# Altium schematic sheet Sheet1.SchDoc
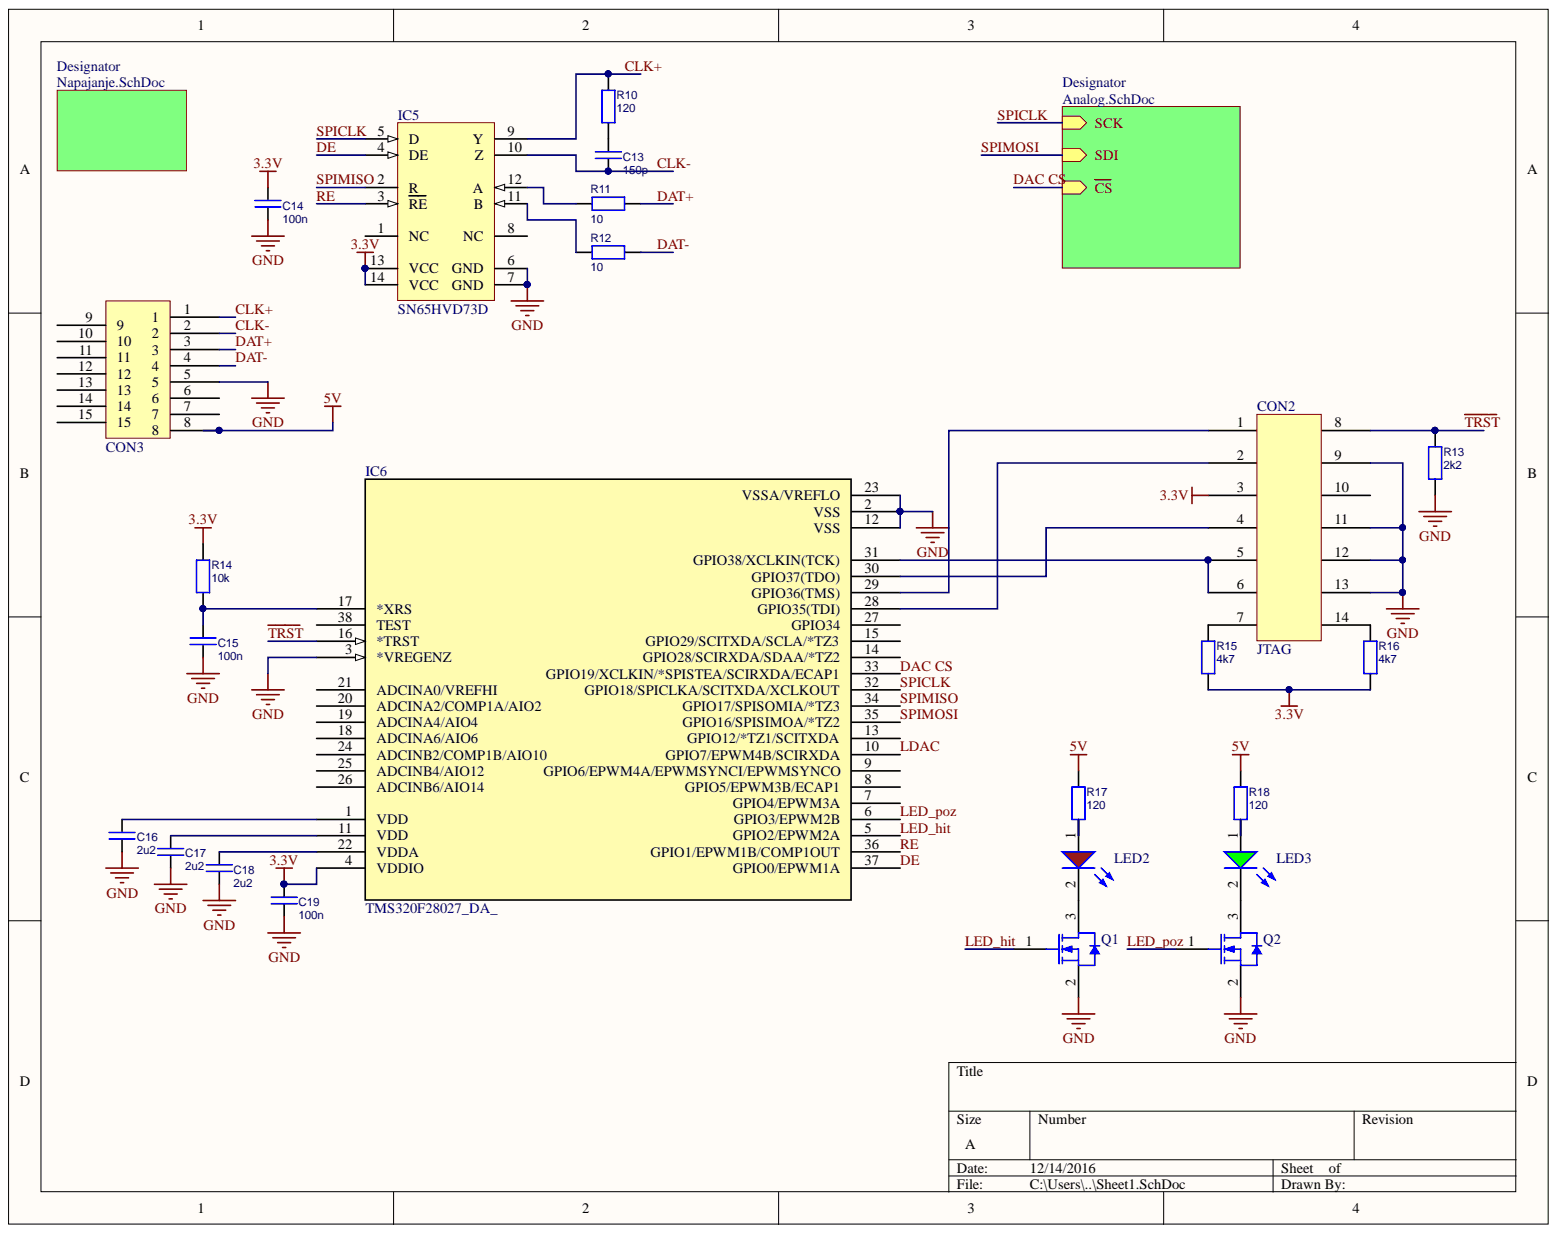
[diagram p0: Sheet1.SchDoc]

## Parts
C13  150p  [C0603]
C14  100n  [C0603]
C15  100n  [C0603]
C16  2u2  [C0603]
C17  2u2  [C0603]
C18  2u2  [C0603]
C19  100n  [C0603]
CON2  JTAG  [JTAG micromatch]
CON3  DSUbConector  [DSUB conector]  (value not drawn)
IC5  SN65HVD73D  [SN75180]
IC6  TMS320F28027_DA_  [TSOP65P810X120-38N]
LED2  150060RS75000  [LED_0603_Red]  (value not drawn)
LED3  150060VS75000  [LED_0603_Bright Green]  (value not drawn)
Q1  BSN20,215  [NXP-SOT23_N]  (value not drawn)
Q2  BSN20,215  [NXP-SOT23_N]  (value not drawn)
R10  120  [R0603]
R11  10  [R0603]
R12  10  [R0603]
R13  value 2k2  [R0805]  (hidden comment: 120)
R14  value 10k  [R0805]  (hidden comment: 120)
R15  value 4k7  [R0805]
R16  value 4k7  [R0805]
R17  120  [R0805]
R18  120  [R0805]

## Nets
3.3V: C14.1 C19.1 CON2.3 IC5.13(VCC) IC5.14(VCC) IC6.4(VDDIO) R14.1 R15.1 R16.1
5V: CON3.8 R17.1 R18.1
GND: C14.2 C15.2 C16.2 C17.2 C18.2 C19.2 CON2.9 CON2.11 CON2.12 CON2.13 CON3.5 IC5.6(GND) IC5.7(GND) IC6.2(VSS) IC6.3(*VREGENZ) IC6.12(VSS) IC6.23(VSSA/VREFLO) Q1.2 Q2.2 R13.1
CLK+: CON3.1 IC5.9(Y) R10.1
CLK-: C13.2 CON3.2 IC5.10(Z)
DAC CS: IC6.33(GPIO19/XCLKIN/*SPISTEA/SCIRXDA/ECAP1)
DAT+: CON3.3 R11.1
DAT-: CON3.4 R12.1
DE: IC5.4(DE) IC6.37(GPIO0/EPWM1A)
LDAC: IC6.10(GPIO7/EPWM4B/SCIRXDA)
LED_HIT: IC6.5(GPIO2/EPWM2A) Q1.1
LED_POZ: IC6.6(GPIO3/EPWM2B) Q2.1
RE: IC5.3(RE) IC6.36(GPIO1/EPWM1B/COMP1OUT)
SPICLK: IC5.5(D) IC6.32(GPIO18/SPICLKA/SCITXDA/XCLKOUT)
SPIMISO: IC5.2(R) IC6.34(GPIO17/SPISOMIA/*TZ3)
SPIMOSI: IC6.35(GPIO16/SPISIMOA/*TZ2)
~{TRST}: CON2.8 IC6.16(*TRST) R13.2
NetC13_1: C13.1 R10.2
NetC15_1: C15.1 IC6.17(*XRS) R14.2
NetC16_1: C16.1 IC6.1(VDD)
NetC17_1: C17.1 IC6.11(VDD)
NetC18_1: C18.1 IC6.22(VDDA)
NetCON2_1: CON2.1 IC6.29(GPIO36(TMS))
NetCON2_2: CON2.2 IC6.28(GPIO35(TDI))
NetCON2_4: CON2.4 IC6.30(GPIO37(TDO))
NetCON2_5: CON2.5 CON2.6 IC6.31(GPIO38/XCLKIN(TCK))
NetCON2_7: CON2.7 R15.2
NetCON2_10: CON2.10
NetCON2_14: CON2.14 R16.2
NetCON3_6: CON3.6
NetCON3_7: CON3.7
NetCON3_9: CON3.9
NetCON3_10: CON3.10
NetCON3_11: CON3.11
NetCON3_12: CON3.12
NetCON3_13: CON3.13
NetCON3_14: CON3.14
NetCON3_15: CON3.15
NetIC5_1: IC5.1(NC)
NetIC5_8: IC5.8(NC)
NetIC5_11: IC5.11(B) R12.2
NetIC5_12: IC5.12(A) R11.2
NetIC6_7: IC6.7(GPIO4/EPWM3A)
NetIC6_8: IC6.8(GPIO5/EPWM3B/ECAP1)
NetIC6_9: IC6.9(GPIO6/EPWM4A/EPWMSYNCI/EPWMSYNCO)
NetIC6_13: IC6.13(GPIO12/*TZ1/SCITXDA)
NetIC6_14: IC6.14(GPIO28/SCIRXDA/SDAA/*TZ2)
NetIC6_15: IC6.15(GPIO29/SCITXDA/SCLA/*TZ3)
NetIC6_18: IC6.18(ADCINA6/AIO6)
NetIC6_19: IC6.19(ADCINA4/AIO4)
NetIC6_20: IC6.20(ADCINA2/COMP1A/AIO2)
NetIC6_21: IC6.21(ADCINA0/VREFHI)
NetIC6_24: IC6.24(ADCINB2/COMP1B/AIO10)
NetIC6_25: IC6.25(ADCINB4/AIO12)
NetIC6_26: IC6.26(ADCINB6/AIO14)
NetIC6_27: IC6.27(GPIO34)
NetIC6_38: IC6.38(TEST)
NetLED2_1: LED2.1 R17.2
NetLED2_2: LED2.2 Q1.3
NetLED3_1: LED3.1 R18.2
NetLED3_2: LED3.2 Q2.3

## Library data of the parts
C13-C19 = C: Capacitor; Component Kind: Standard; Library Name: General.IntLib
  C13: Value: 150p
  C14 C15 C19: Value: 100n
  C16-C18: Value: 2u2
IC5 = SN65HVD55DR: High Output Full-Duplex RS-485 Driver and Receiver, 1 Mbps, 5 V, -40 to 85 degC, 14-pin SOIC (D), Green (RoHS & no Sb/Br); Manufacturer: Texas Instruments; # of TX/RX: 1 TX / 1 RX; Common Mode Range: -7 to 12; Drivers Per Package: 1; Duplex: Full; ESD(kV): 16; Fail Safe: Idle, Open, Short; Fault Protection(V): -9 to 14; ICC(Max)(mA): 8; Number of Nodes: 256; Rating: Catalog; Supply Voltage(s)(V): 5; Code_JEDEC: MS-012AB; Component Kind: Standard; ComponentLink1Description: Datasheet; ComponentLink1URL: http://www.ti.com/general/docs/lit/getliterature.tsp?genericPartNumber=SN65HVD55&fileType=pdf; ComponentLink2Description: Package Specification; ComponentLink2URL: http://www.ti.com/litv/pdf/mpds177g; DatasheetVersion: SLLS666E; Eco-Plan: Green (RoHS & no Sb/Br); Isolated: No; Library Name: Texas Instruments Interface RS-485.IntLib; Mounting Technology: Surface Mount; PackageDescription: 14-Pin Plastic Small Outline Integrated Circuit, 8.7 x 3.9 mm, Pitch 1.27 mm Pitch; PackageReference: D14; PackageVersion: revM, Jun-2011; PartNumber: SN65HVD55DR; Receivers Per Package: 1; Signaling Rate(Mbps): 1; SubFamily: RS-485; Supplier 1: Digi-Key; Supplier 2: Digi-Key; Supplier 3: Digi-Key; Supplier 4: Mouser; Supplier 5: Farnell; Supplier Part Number 1: 296-18709-2-ND; Supplier Part Number 2: 296-18709-1-ND; Supplier Part Number 3: 296-18709-6-ND; Supplier Part Number 4: 595-SN65HVD55DR; Supplier Part Number 5: 2455484
IC6 = TMS320F28027_DA_: Imported; Manufacturer_Part_Number: tms320f28027_da_38; Vendor: Texas Instruments; Component Kind: Standard; Library Name: 2016-11-04_16-51-30.SchLib; RefDes: RefDes; Supplier 1: Farnell; Supplier Part Number 1: 2076786; Type: DEV; Value: Value
LED2 = WL-SMCW 0603  150060RS75000: SMD mono-color Chip LED, WL-SMCW, Red; Manufacturer: W黵th Elektronik eiSos GmbH; Color: Red; Component Kind: Standard; ComponentLink1Description: Manufacturer; ComponentLink1URL: http://www.we-online.de; ComponentLink2Description: Datasheet; ComponentLink2URL: http://katalog.we-online.com/led/datasheet/150060RS75000.pdf; Created Date: June 2013; Library Name: WL-SMCW_rev15b.intlib; PartNo: 150060RS75000; Supplier 1: Farnell; Supplier Part Number 1: 2322071; Created by: Christin Otto
LED3 = WL-SMCW 0603  150060VS75000: SMD mono-color Chip LED, WL-SMCW, Bright Green; Manufacturer: W黵th Elektronik eiSos GmbH; Color: Bright Green; Component Kind: Standard; ComponentLink1Description: Manufacturer; ComponentLink1URL: http://www.we-online.de; ComponentLink2Description: Datasheet; ComponentLink2URL: http://katalog.we-online.com/led/datasheet/150060VS75000.pdf; Created Date: June 2013; Library Name: WL-SMCW_rev15b.intlib; PartNo: 150060VS75000; Supplier 1: Farnell; Supplier Part Number 2: 2322073; Created by: Christin Otto
Q1 Q2 = BSN20,215: N-Channel Enhancement Mode Field-Effect Transistor, 50 V, 0.173 A, 3-Pin SOT23, Tape and Reel; Manufacturer: NXP Semiconductors; Channel Type: N; Ciss [typ] (pF): 17; Coss [typ] (pF): 7; ID [max] (A): 0.173; Packing: Tape & Reel; Qr [typ] (nC): 30; VDS [max] (V): 50; VGSth [typ] (V): 1; Code_JEDEC: TO-236AB; Component Kind: Standard; ComponentLink1Description: Manufacturer URL; ComponentLink1URL: http://www.nxp.com/; ComponentLink2Description: Datasheet; ComponentLink2URL: http://www.nxp.com/documents/data_sheet/BSN20.pdf; ComponentLink3Description: Package Specification; ComponentLink3URL: http://www.nxp.com/documents/outline_drawing/sot023_po.pdf; DatasheetVersion: Rev. 03; Library Name: NXP Semiconductors Standard MOSFETs.IntLib; Mounting Technology: Surface Mount; PackageDescription: 3-Pin Small Outline Transistor Package, Body 2.9 x 1.3 mm, Pitch 0.95 mm; PackageReference: SOT23; PackageVersion: 06-03-16, 03/2006; PartNumber: BSN20,215; Supplier 6: Farnell; Supplier Part Number 6: 1081309
R10-R18 = R: Component Kind: Standard; Description: R; Library Name: General.IntLib
  R10: Value: 120
  R11 R12: Value: 10
  R14: Supplier 1: Farnell; Supplier Part Number 1: 9332596
  R17 R18: Supplier 1: Farnell; Supplier Part Number 1: 9332596; Value: 120
CON2 = JTAG: Component Kind: Standard; Library Name: 2016-11-04_16-51-30.SchLib; Supplier 1: Farnell; Supplier Part Number 1: 148647
CON3 = DSUbConector: Component Kind: Standard; Library Name: 2016-11-04_16-51-30.SchLib; Supplier 1: Farnell; Supplier Part Number 1: 1099295
